# Altium schematic sheet Stage3A_Linear_Regulator_controller.SchDoc
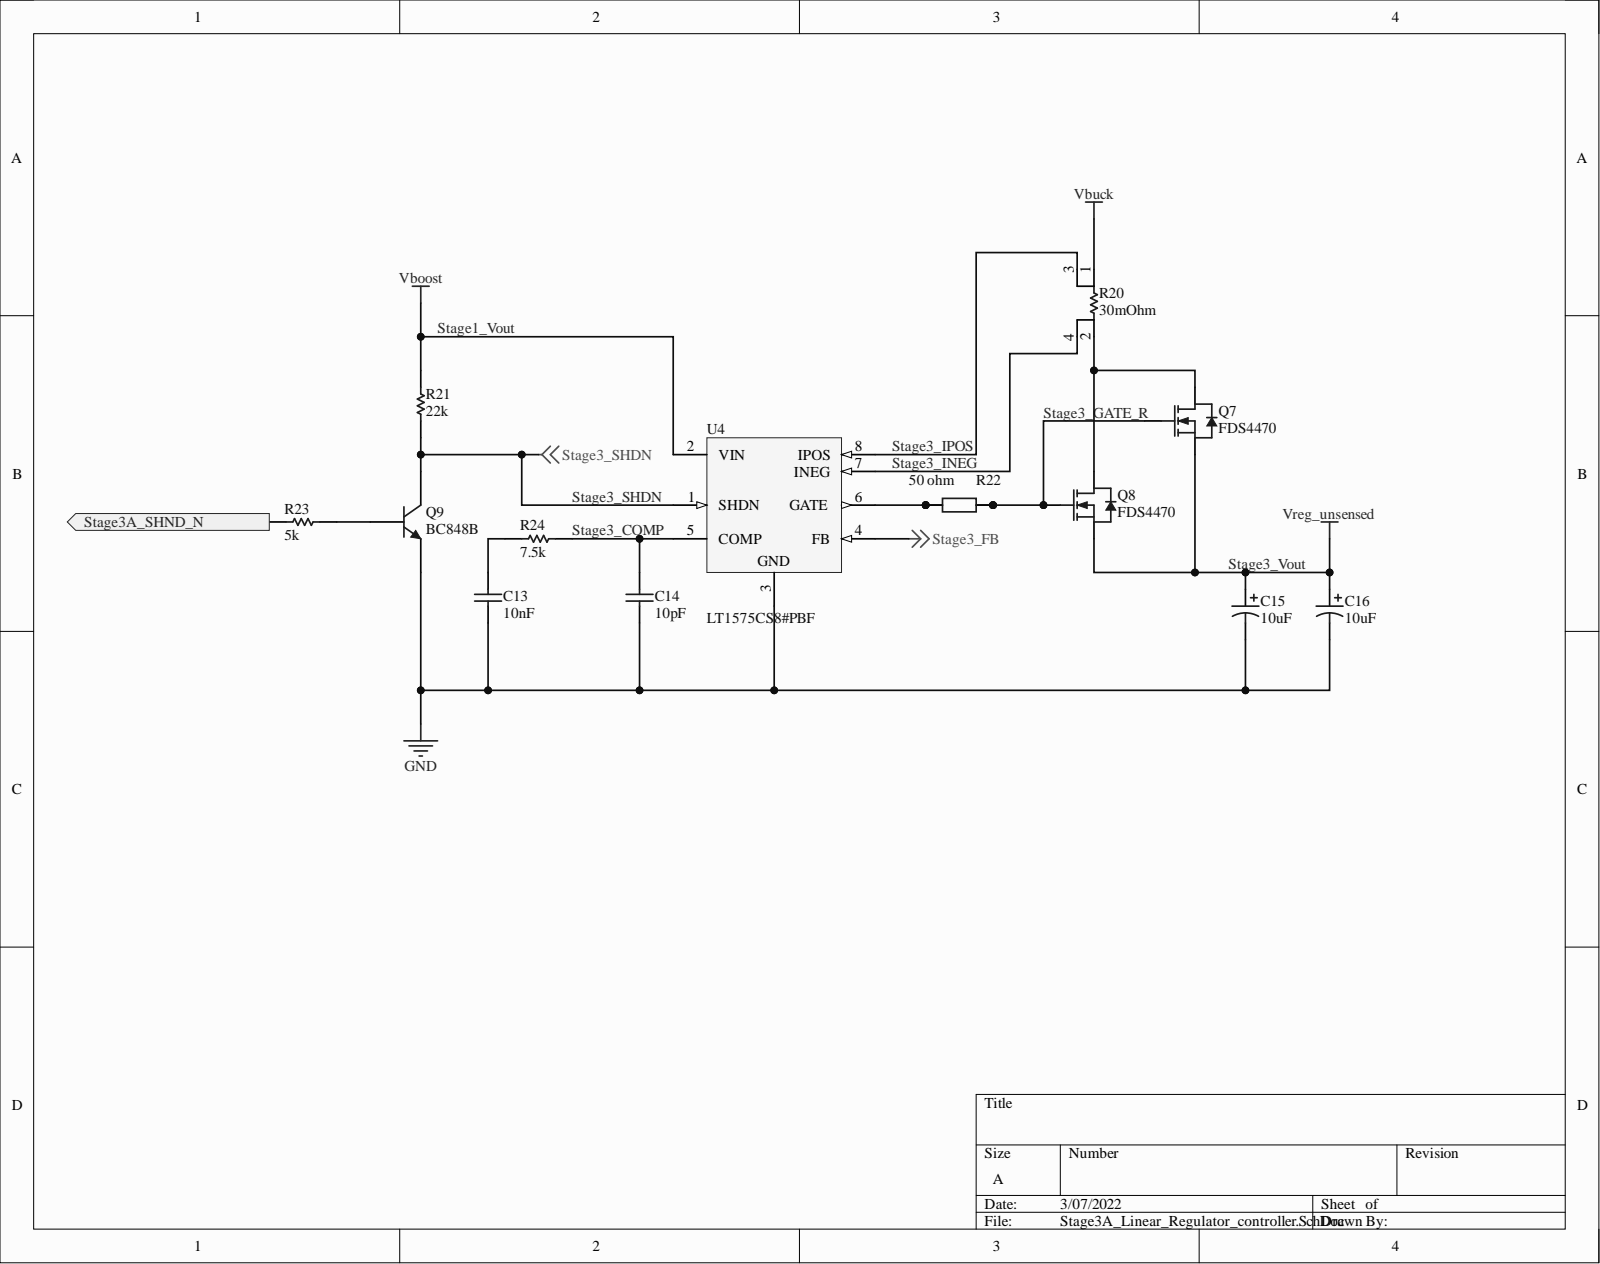
[diagram p0: Stage3A_Linear_Regulator_controller.SchDoc]

## Parts
C13  10nF  [PCB-vcjr8nxpwfuh09uwlcd6-1]
C14  10pF  [CAPC2013X94X50NL20T25]
C15  10uF  [PCB-45uljczbzgwzo9m7ql45-1]
C16  10uF  [PCB-45uljczbzgwzo9m7ql45-1]
Q7  FDS4470  [PCB-vam1no1ldi8601k0q85a-1]
Q8  FDS4470  [PCB-vam1no1ldi8601k0q85a-1]
Q9  BC848B  [PCB-hbuntr9r1m1073lqpw4t-1]
R20  30mOhm  [PCB-e4z1ou8eehx9zfei5nig-1]
R21  22k  [PCB-0002-00354-1]
R22  50 ohm  [PCB-7mdwqy8yim8csor4mvd5-1]
R23  5k  [PCB-uip3f7nxj24hdkeeu2m5-1]
R24  7.5k  [RESC2013X70X40LL20T20]
U4  LT1575CS8#PBF  [LT-S8-8_M]

## Ports
Stage3A_SHND_N

## Nets
GND: C13.1 C14.1 C15.2 C16.2 Q9.3 U4.3
Stage3_FB: U4.4(FB)
Vbuck: R20.1
Stage1_Vout: R21.2 U4.2(VIN)
Stage3_COMP: C14.2 R24.2 U4.5(COMP)
Stage3_GATE_R: Q7.1 Q8.1 R22.2
Stage3_INEG: R20.4 U4.7(INEG)
Stage3_IPOS: R20.3 U4.8(IPOS)
Stage3_SHDN: Q9.1 R21.1 U4.1(SHDN)
Stage3_Vout: C15.1 C16.1 Q7.2 Q8.2
Stage3A_SHND_N: R23.1 ; port Stage3A_SHND_N
NetC13_2: C13.2 R24.1
NetQ7_3: Q7.3 Q8.3 R20.2
NetQ9_2: Q9.2 R23.2
NetR22_1: R22.1 U4.6(GATE)

## Library data of the parts
C13 = CMP-526e9b1a13078da9-3: CL21 Series 10 nF 50V ±10 % Tolerance X7R SMT Multilayer Ceramic Capacitor; Manufacturer Part Number: CL21B103KBANNNC; Capacitance: 1e-08 F; Capacitance Tolerance: ±10%; Lead-Free Status: Lead Free; Voltage Rating (DC): 50.0 V; Case/Package: 0805; Component Kind: Standard; Dielectric Characteristic: X7R; Library Name: My Personal Space; Mounting Style: Surface Mount; Octopart URL: http://octopart.com/cl21b103kbannnc-samsung-8283151; OctopartID: 526e9b1a13078da9; RoHS: Compliant
C14 = CMP-128474-2: CAP CER 10PF 100V 5% NP0 0805; Manufacturer Part Number: 08051A100JAT2A; Capacitance: 1e-11 F; Case/Package (SI): 2012; Dielectric Characteristic: C0G/NP0; Dielectric Material: Ceramic Multilayer; Insulation Resistance: 1e+11 Ω; Lead-Free Status: Lead Free; Temperature Coefficient: 0.0 ppm/°C; Voltage Rating (DC): 100.0 V; Capacitance Tolerance: ±5%; Case/Package: 0805; Component Kind: Standard; Library Name: My Personal Space; Mounting Style: Surface Mount; Number of Pins: 2; Octopart Url: https://octopart.com/08051a100jat2a-avx+interconnect+%2F+elco-40099703; OctopartID: de1d1574240c01da; REACH SVHC Compliance: No SVHC; RoHS: Compliant; Size-Height: 0.00071 m; Size-Length: 0.0020066 m; Size-Thickness: 0.0009398 m; Size-Width: 0.00125 m
C15 C16 = CMP-7610bd40367da9d7-6: Capacitor; Aluminum Electrolytic; Fc Radial Elec Cap 10uF 50V; Manufacturer Part Number: EEU-FC1H100L; Capacitance: 10.0 µF; Capacitance Tolerance: ±20%; Lead-Free Status: Lead Free; Voltage Rating (DC): 50.0 V; Component Kind: Standard; Dielectric Material: Aluminum Electrolytic; Library Name: My Personal Space; Lifecycle Status: Not Listed by Manufacturer; Mounting Style: Through Hole; Number of Pins: 2; Octopart URL: https://octopart.com/eeu-fc1h100l-panasonic-39478539; OctopartID: 7610bd40367da9d7; Operating Temperature: 105 °C (max); Packaging: Bulk; REACH SVHC Compliance: No SVHC; RoHS: Compliant; Size-Diameter: 5.00 mm; Size-Height: 11.0 mm; Size-Length: 11.0 mm
Q7 Q8 = CMP-cab003bfccd71577-2: FDS4470 Series 40 V 9 mOhm Surface Mount N-Channel PowerTrench Mosfet - SOIC-8; Breakdown Voltage (Drain to Source): -100.0 V; Continuous Drain Current (Ids): 12.5 A; Drain to Source Resistance (on) (Rds): 0.009 Ω; Drain to Source Voltage (Vds): 40.0 V; Gate Charge: 4.5e-08 C; Input Capacitance: 2.659e-09 F; Lead-Free Status: Lead Free; Power Dissipation: 2.5 W; Rise Time: 1.2e-08 s; Case/Package: SOIC; Component Kind: Standard; Current Rating: 12.5 A; Library Name: My Personal Space; Lifecycle Status: Active; Manufacturer Part Number: FDS4470; Mounting Style: Surface Mount; Number of Pins: 8; Octopart URL: http://octopart.com/fds4470-fairchild+semiconductor-336641; OctopartID: cab003bfccd71577; Packaging: Tape; Polarity: N-Channel; REACH SVHC Compliance: No SVHC; RoHS: Compliant; Voltage Rating (DC): 40.0 V
Q9 = CMP-64f7a94c3727bb30-1: BC848; Manufacturer Part Number: BC848B,215; Lead-Free Status: Lead Free; Polarity: NPN, N-Channel; Case/Package: SOT-23; Component Kind: Standard; Library Name: My Personal Space; Lifecycle Status: Active; Mounting Style: Surface Mount; Number of Pins: 3; Octopart URL: https://octopart.com/bc848b%2C215-nexperia-78739126; OctopartID: 64f7a94c3727bb30; Operating Temperature: -65.0 °C to 150 °C; Packaging: Cut Tape (CT), Tape & Reel (TR); REACH SVHC Compliance: No SVHC; RoHS: Compliant
R20 = CMP-41418819abb09e86-1: Res Metal Alloy 1206 0.03 Ohm 0.5% 0.5W(1/2W) ±50ppm/C Epoxy Resin Pad SMD T/R; Manufacturer Part Number: LVK12R030DER; Case/Package (SI): 3216; Power Rating: 500 mW; Resistance: 30.0 mΩ; Temperature Coefficient: -50.0 ppm/°C to 50.0 ppm/°C; Case/Package: 3216, 1206; Component Kind: Standard; Library Name: My Personal Space; Mounting Style: Surface Mount; Number of Pins: 2; Octopart URL: https://octopart.com/lvk12r030der-ohmite-5427095; OctopartID: 41418819abb09e86; Operating Temperature: -40.0 °C to 125 °C; Packaging: Tape & Reel (TR), Cut Tape (CT); Resistance Tolerance: ±0.5%; RoHS: Compliant
R21 = CMP-661aaa7c9094b9dd-1: Res General Purpose Thick Film 0805 22k Ohm 5% 1/8W ±100ppm/°C Molded Paper T/R; Manufacturer Part Number: CRGCQ0805J22K; Case/Package (SI): 2012; Power Rating: 125 mW; Resistance: 22.0 kΩ; Temperature Coefficient: -100 ppm/°C to 100 ppm/°C; Voltage Rating (DC): 150 V; Case/Package: 2012, 0805; Component Kind: Standard; Library Name: My Personal Space; Lifecycle Status: Not Listed by Manufacturer; Octopart URL: https://octopart.com/crgcq0805j22k-te+connectivity-91018216; OctopartID: 661aaa7c9094b9dd; Packaging: Tape & Reel (TR); Resistance Tolerance: ±5%; RoHS: Compliant
R22 = CMP-c5c70d8b84031a00-2: Res Thick Film 0805 50 Ohm 1% 1/8W ±100ppm/°C Molded SMD Paper T/R; Manufacturer Part Number: CRCW080550R0FKTA; Lead-Free Status: Contains Lead; Power Rating: 125 mW; Resistance: 50.0 Ω; Case/Package: 0805; Component Kind: Standard; Library Name: My Personal Space; Mounting Style: Surface Mount; Octopart URL: https://octopart.com/crcw080550r0fkta-vishay-21390498; OctopartID: c5c70d8b84031a00; Packaging: Reel; Resistance Tolerance: ±1%; RoHS: Non-Compliant
R23 = CMP-68e4a35bf371b723-1: Res Thick Film 0805 0.82 Ohm 5% 0.25W(1/4W) ±200ppm/C Epoxy SMD Automotive T/R; Manufacturer Part Number: RLP73K2AR82JTD; Case/Package (SI): 2012; ELV Compliant: Compliant; Power Rating: 250 mW; Resistance: 820 mΩ; Case/Package: 0805, 2012; Component Kind: Standard; Library Name: My Personal Space; Lifecycle Status: Not Listed by Manufacturer; Mounting Style: Surface Mount; Octopart URL: http://octopart.com/rlp73k2ar82jtd-te+connectivity-45465331; OctopartID: 68e4a35bf371b723; Packaging: Tape & Reel (TR); REACH SVHC Compliance: No SVHC; Resistance Tolerance: ±5%; RoHS: Compliant
R24 = CMP-3651151-2: Thick Film Resistors 0805 7.5Kohms 1% Tol; Manufacturer Part Number: ERJ-6ENF7501V; Case/Package (SI): 2012; Lead-Free Status: Contains Lead; Power Rating: 0.125 W; Resistance: 7500.0 Ω; Voltage Rating (DC): 150.0 V; Case/Package: 0805; Component Kind: Standard; Library Name: My Personal Space; Lifecycle Status: Not Listed by Manufacturer; Mounting Style: Surface Mount; Octopart Url: https://octopart.com/erj-6enf7501v-panasonic-55422813; OctopartID: ec0a264d663133cd; Packaging: Cut Tape (CT); REACH SVHC Compliance: No SVHC; Resistance Tolerance: ±1%; RoHS: Compliant; Size-Height: 0.0006 m; Size-Length: 0.002 m; Size-Width: 0.00125 m
U4 = CMP-1517602-2: Ultrafast Transient Response, Low Dropout Regulator, 10 to 20 V Vin, Adjustable Vout, 8-pin SOIC (S8-8), 0 to 70 degC, Pb-Free; Lead-Free Status: Lead Free; Number of Outputs: 1; Output Current: 0.0015 A; Case/Package: SOIC; Component Kind: Standard; Library Name: My Personal Space; Manufacturer Part Number: LT1575CS8#PBF; Mounting Style: Surface Mount; Number of Pins: 8; Octopart Url: https://octopart.com/lt1575cs8%23pbf-linear+technology-533255; OctopartID: 4e8f878d48ee9148; Packaging: Bulk; REACH SVHC Compliance: No SVHC; RoHS: Compliant
